# Altium schematic sheet Keyboard.SchDoc
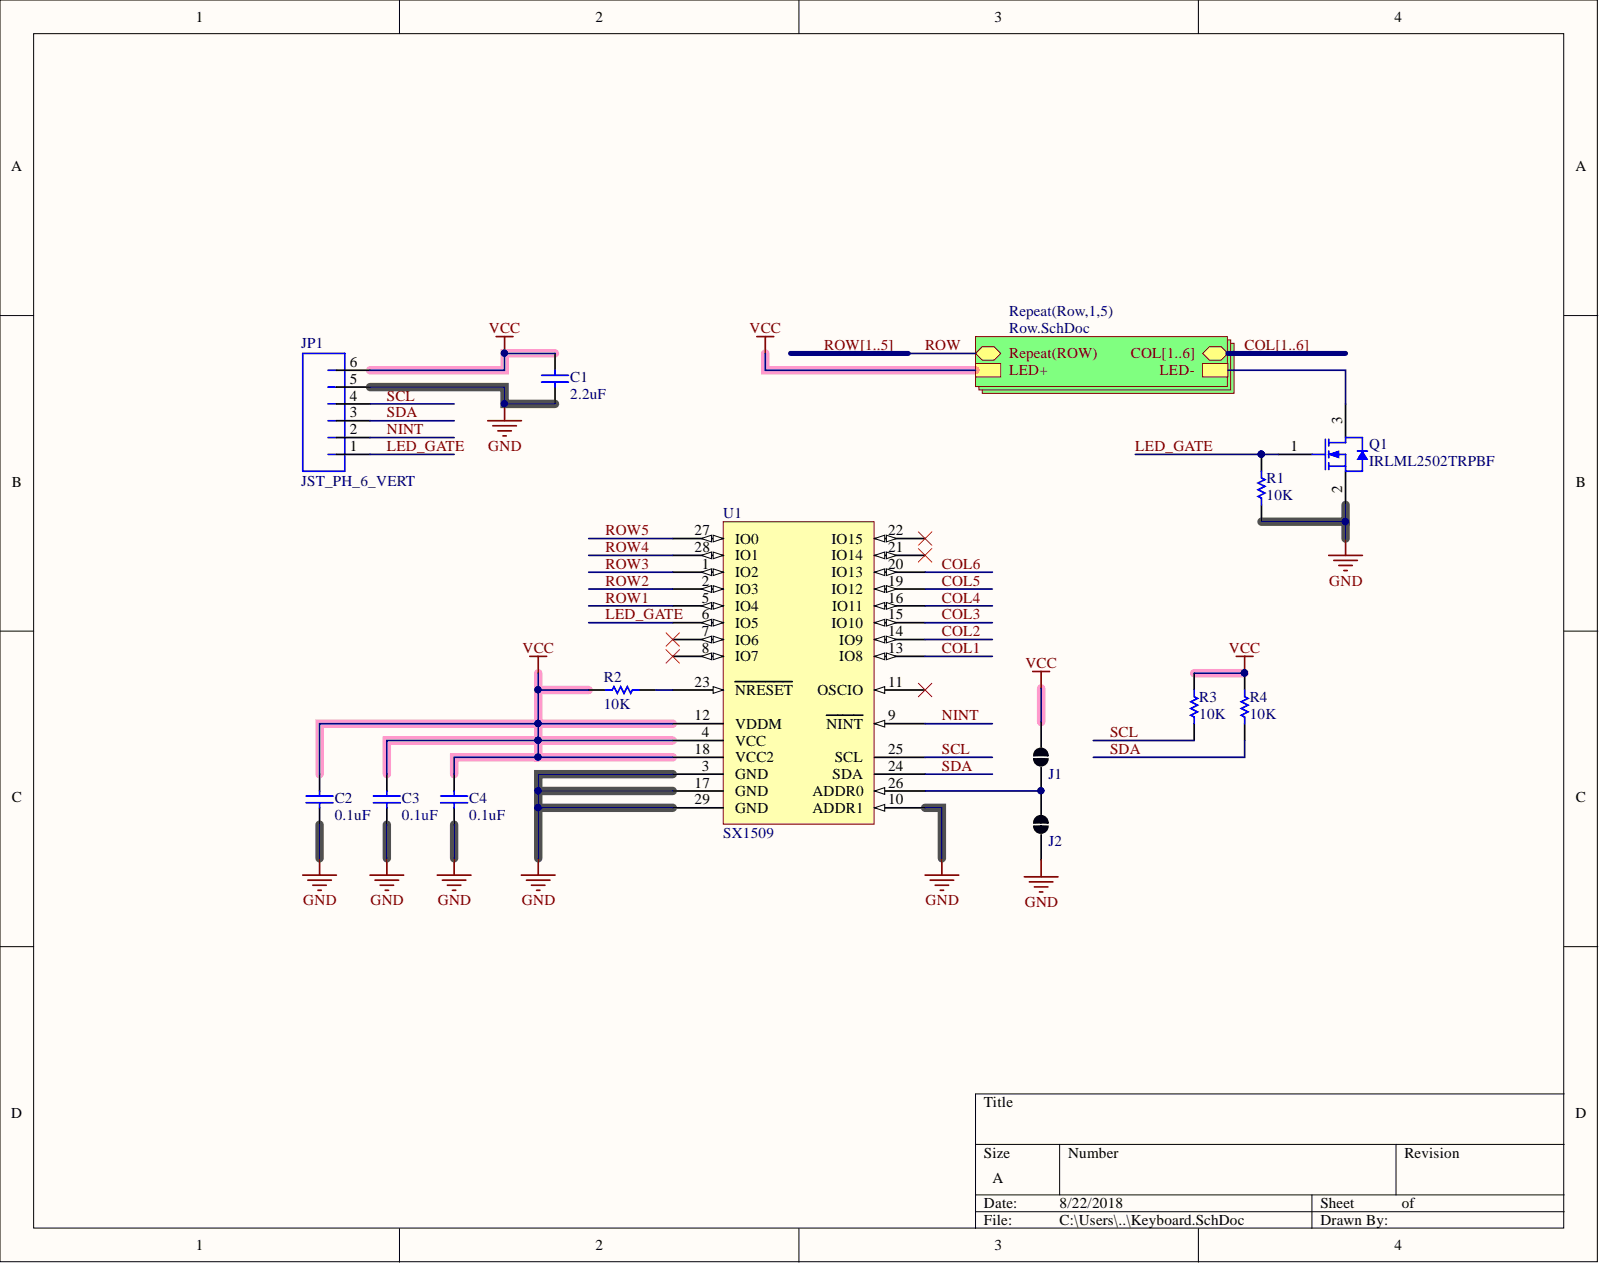
[diagram p0: Keyboard.SchDoc]

## Parts
C1  2.2uF  [CAPC0603(1608)100_N]
C2  0.1uF  [CAPC0603(1608)100_N]
C3  0.1uF  [CAPC0603(1608)100_N]
C4  0.1uF  [CAPC0603(1608)100_N]
J1  ADDR_H  [SOLDER JUMPER]  (value not drawn)
J2  ADDR_L  [SOLDER JUMPER]  (value not drawn)
JP1  JST_PH_6_VERT  [JST_PH_6_MIRRORABLE]
Q1  IRLML2502TRPBF  [INTR-SOT-23-3_V]
R1  10K  [RESC0603(1608)_N]
R2  10K  [RESC0603(1608)_N]
R3  10K  [RESC0603(1608)_N]
R4  10K  [RESC0603(1608)_N]
U1  SX1509  [QFN_UT_28]

## Nets
GND: C1.2 C2.1 C3.1 C4.1 J2.1 JP1.5 Q1.2 R1.1 U1.3(GND) U1.10(ADDR1) U1.17(GND) U1.29(GND)
VCC: C1.1 C2.2 C3.2 C4.2 J1.2 JP1.6 R2.2 R3.1 R4.1 U1.4(VCC) U1.12(VDDM) U1.18(VCC2)
COL1: U1.13(IO8)  [also labelled COL]
COL2: U1.14(IO9)  [also labelled COL]
COL3: U1.15(IO10)  [also labelled COL]
COL4: U1.16(IO11)  [also labelled COL]
COL5: U1.19(IO12)  [also labelled COL]
COL6: U1.20(IO13)  [also labelled COL]
LED_GATE: JP1.1 Q1.1 R1.2 U1.6(IO5)
NINT: JP1.2 U1.9(NINT)
ROW1: U1.5(IO4)  [also labelled ROW]
ROW2: U1.2(IO3)  [also labelled ROW]
ROW3: U1.1(IO2)  [also labelled ROW]
ROW4: U1.28(IO1)  [also labelled ROW]
ROW5: U1.27(IO0)  [also labelled ROW]
SCL: JP1.4 R3.2 U1.25(SCL)
SDA: JP1.3 R4.2 U1.24(SDA)
NetJ1_1: J1.1 J2.2 U1.26(ADDR0)
NetQ1_3: Q1.3
NetR2_1: R2.1 U1.23(NRESET)
NetU1_7: U1.7(IO6)
NetU1_8: U1.8(IO7)
NetU1_11: U1.11(OSCIO)
NetU1_21: U1.21(IO14)
NetU1_22: U1.22(IO15)

## Library data of the parts
C1 = CMP-1035-02767-1: CAP 2.2uF 25V ±10% 0603 (1608 Metric) Thickness 1mm SMD; Case-EIA: 0603; Case-Metric: 1608; Tolerance: Â±10%; Component Kind: Standard; Library Name: Altium Content Vault; Max Thickness: 1 mm; Rated Voltage: 25 V; Technology: SMT; Value: 2.2uF
C2-C4 = CMP-1035-02595-1: CAP 100nF 100V ±10% 0603 (1608 Metric) Thickness 1mm SMD; Case-EIA: 0603; Case-Metric: 1608; Tolerance: Â±10%; Component Kind: Standard; Library Name: Altium Content Vault; Max Thickness: 1 mm; Rated Voltage: 100 V; Technology: SMT; Value: 100nF
J1 J2 = Solder Jumper: Component Kind: Standard; Library Name: Keyboard.SchLib
JP1 = JST_PH_6_VERT: Manufacturer: JST Sales America Inc.; PartNumber: B6B-PH-K-S(LF)(SN); Component Kind: Standard; Library Name: Keyboard.SchLib; Mounting Technology: Through Hole; PackageReference: JST-PH
Q1 = CMP-2000-04985-1: N-Channel HEXFET(R) Power MOSFET, 20 V VDS, +/- 12 V VGS, 4.2 A, 3-Pin SOT23, RoHS, Tape and Reel; Manufacturer: International Rectifier; Drain-Source On Resistance-Max: 0.045O; Drain-to-Source Voltage [Vdss]: 20V; Qg Gate Charge: 8nC; Rated Power Dissipation: 1.25W; Channel Type: N-Channel; Component Kind: Standard; Datasheet URL: https://datasheet.ciiva.com/6383/irlml2502pbf-6383216.pdf; Datasheet Version: Apr-14; Library Name: Altium Content Vault; Manufacturer URL: http://internationalrectifier.com/; Package Description: 3-Pin Small Outline Transistor, Body 2.92 x 1.3 mm, Pitch 0.95 mm; Package Reference: SOT-23-3
R1-R4 = CMP-1012-00098-1: 10K 0.1W 5% 0603 (1608 Metric)  SMD; Case-EIA: 0603; Case-Metric: 1608; Value: 10000.00 Ohm; Component Kind: Standard; Library Name: Altium Content Vault; Power: 0.1 W; Technology: SMT; Tolerance: 5 %
U1 = SX1509: Component Kind: Standard; Library Name: Keyboard.SchLib; Supplier 1: Mouser; Supplier 2: Digi-Key; Supplier 3: Digi-Key; Supplier Part Number 1: 947-SX1509BIULTRT; Supplier Part Number 2: SX1509BIULDKR-ND; Supplier Part Number 3: SX1509BIULCT-ND
